# Altium schematic sheet power_do.SchDoc(power_do)
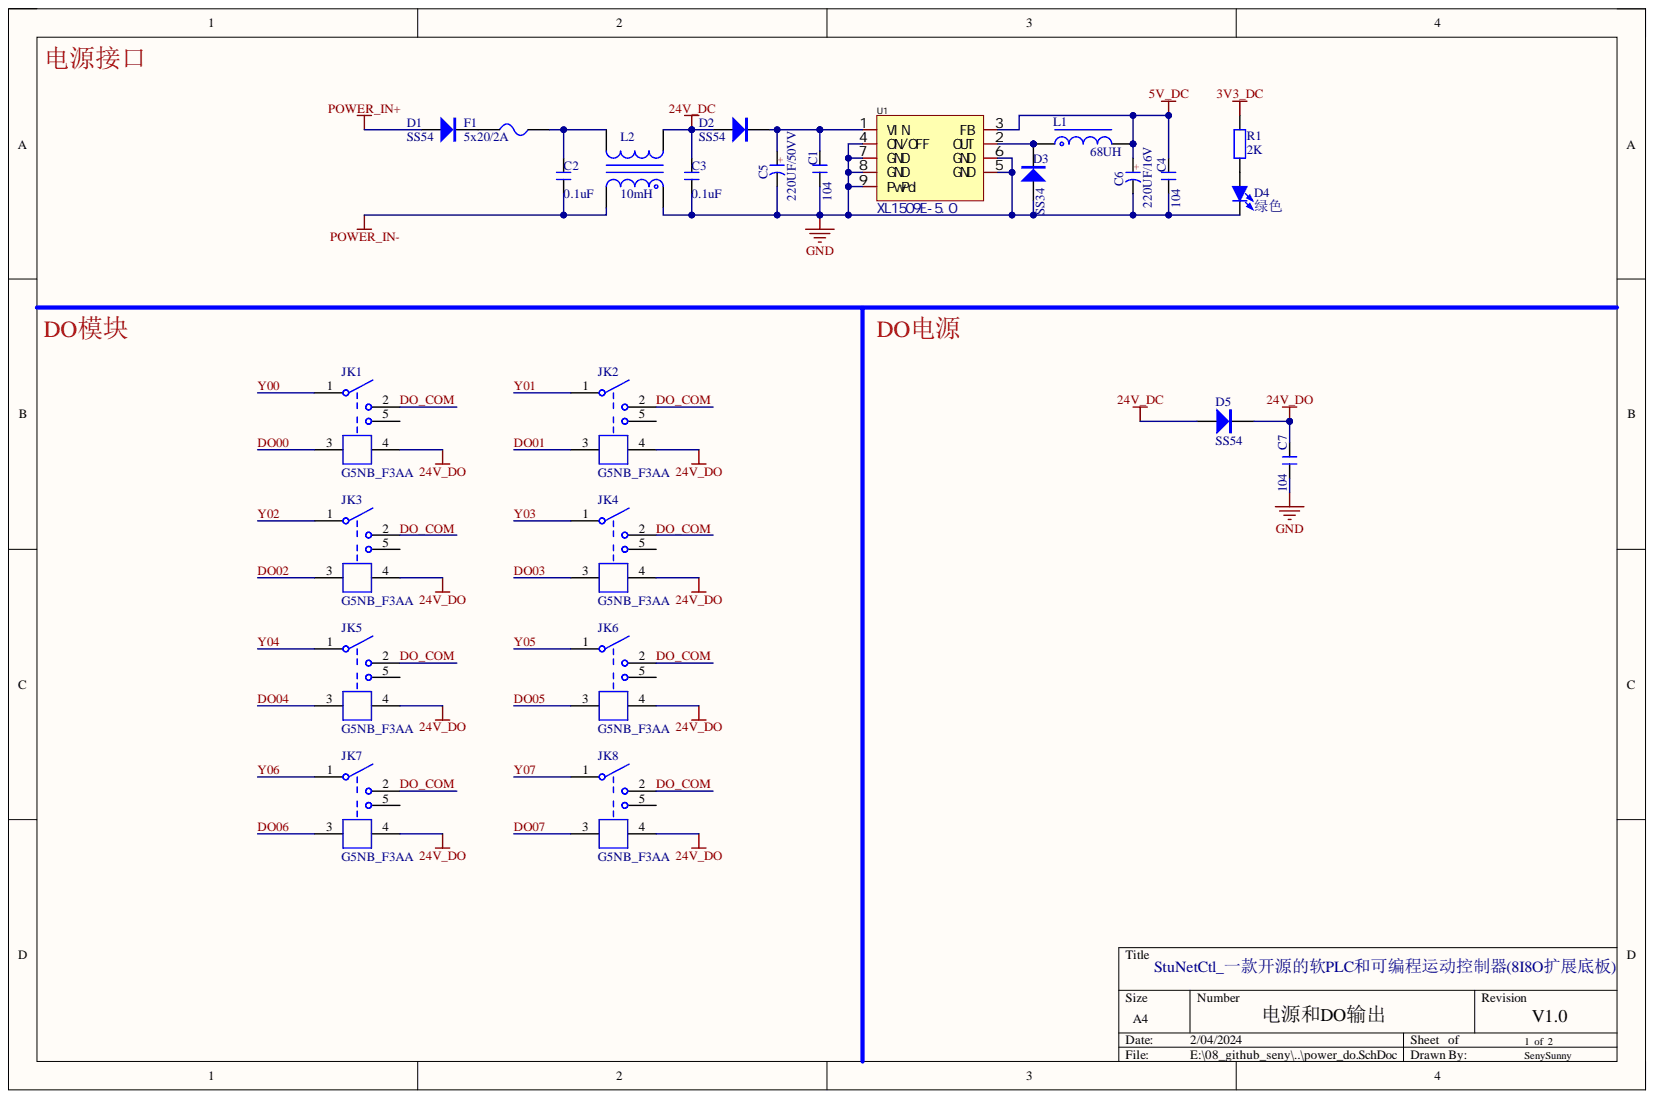
[diagram p0: power_do.SchDoc]

## Parts
C1  104  [C 0603]
C2  0.1uF  [CBB 6.3*6.3*5]
C3  0.1uF  [CBB 6.3*6.3*5]
C4  104  [C 0603]
C5  220UF/50VV  [CAP 5.0*10*12.5 - BK]
C6  220UF/16V  [CAP 2.5*6.3*11.2 - BK]
C7  104  [C 0603]
D1  SS54  [SMA(DO214AC)]
D2  SS54  [SMA(DO214AC)]
D3  SS34  [SMA(DO214AC)]
D4  绿色  [LED 0603G]
D5  SS54  [SMA(DO214AC)]
F1  5x20/2A  [FU RL30-200]
JK1  G5NB_F3AA  [G5NB_F3AA]
JK2  G5NB_F3AA  [G5NB_F3AA]
JK3  G5NB_F3AA  [G5NB_F3AA]
JK4  G5NB_F3AA  [G5NB_F3AA]
JK5  G5NB_F3AA  [G5NB_F3AA]
JK6  G5NB_F3AA  [G5NB_F3AA]
JK7  G5NB_F3AA  [G5NB_F3AA]
JK8  G5NB_F3AA  [G5NB_F3AA]
L1  68UH  [CDRH127]
L2  10mH  [UU9.8]
R1  2K  [R 0603]
U1  XL1509E-5.0  [SOP8P_N]

## Nets
3V3_DC: R1.1
5V_DC: C4.2 C6.1 L1.2 U1.3(FB)
24V_DC: C3.2 D2.1 D5.1 L2.4
24V_DO: C7.1 D5.2 JK1.4 JK2.4 JK3.4 JK4.4 JK5.4 JK6.4 JK7.4 JK8.4
GND: C1.1 C3.1 C4.1 C5.2 C6.2 C7.2 D3.1 D4.2 L2.1 U1.4(ON/OFF) U1.5(GND) U1.6(GND) U1.7(GND) U1.8(GND) U1.9(PwPd)
POWER_IN+: D1.1
POWER_IN-: C2.1 L2.2
DO00: JK1.3
DO01: JK2.3
DO02: JK3.3
DO03: JK4.3
DO04: JK5.3
DO05: JK6.3
DO06: JK7.3
DO07: JK8.3
DO_COM: JK1.2 JK2.2 JK3.2 JK4.2 JK5.2 JK6.2 JK7.2 JK8.2
Y00: JK1.1
Y01: JK2.1
Y02: JK3.1
Y03: JK4.1
Y04: JK5.1
Y05: JK6.1
Y06: JK7.1
Y07: JK8.1
NetC1_2: C1.2 C5.1 D2.2 U1.1(VIN)
NetC2_2: C2.2 F1.1 L2.3
NetD1_2: D1.2 F1.2
NetD3_2: D3.2 L1.1 U1.2(OUT)
NetD4_1: D4.1 R1.2

Not connected: JK1 5; JK2 5; JK3 5; JK4 5; JK5 5; JK6 5; JK7 5; JK8 5

## Library data of the parts
C1 C4 C7 = C: 无极性贴片电容; 版权归属: 源创客; BOM名称: 无极性贴片电容; Component Kind: Standard; 学习资源: www.ooocke.com; Library Name: zblib_v1.IntLib
C2 C3 = C-CBB: CBB电容; 版权归属: 源创客; BOM名称: CBB电容; Component Kind: Standard; 学习资源: www.ooocke.com; Library Name: zblib_v1.IntLib
C5 C6 = C-CAP: 直插电解电容; 版权归属: 源创客; BOM名称: 直插电解电容; Component Kind: Standard; 学习资源: www.ooocke.com; Library Name: zblib_v1.IntLib
D1-D3 D5 = SS14: 肖特基二极管; 属性: 40V/1A; 版权归属: 源创客; BOM名称: 肖特基二极管; Component Kind: Standard; 学习资源: www.ooocke.com; Library Name: zblib_v1.IntLib
D4 = LED-SMD: 贴片LED; 版权归属: 源创客; BOM名称: 贴片LED; Component Kind: Standard; 学习资源: www.ooocke.com; Library Name: zblib_v1.IntLib
F1 = FU DIP: 直插保险丝; 版权归属: 源创客; BOM名称: 直插保险丝; Component Kind: Standard; 学习资源: www.ooocke.com; Library Name: zblib_v1.IntLib
JK1-JK8 = G5NB_F3AA: 单路常开继电器; 版权归属: 源创客; BOM名称: 单路常开继电器; Component Kind: Standard; 学习资源: www.ooocke.com; Library Name: zblib_v1.IntLib
L1 = L-CDRH: 功率屏蔽电感; 版权归属: 源创客; BOM名称: 功率屏蔽电感; Component Kind: Standard; 学习资源: www.ooocke.com; Library Name: zblib_v1.IntLib
L2 = L-CMC: 共模电感; 版权归属: 源创客; BOM名称: 共模电感; Component Kind: Standard; 学习资源: www.ooocke.com; Library Name: zblib_v1.IntLib
R1 = R: 贴片电阻; 版权归属: 源创客; BOM名称: 贴片电阻; Component Kind: Standard; 学习资源: www.ooocke.com; Library Name: zblib_v1.IntLib
U1 = XL1509E-5.0: DC-DC电源芯片; Manufacturer: UMW(广东友台半导体); Add into BOM: yes; Device: XL1509-5.0; Symbol: XL1509-5.0_C2902368; Component Kind: Standard; Convert to PCB: yes; Datasheet: https://atta.szlcsc.com/upload/public/pdf/source/20230412/A4F395BE4384B04485E6C784EB623363.pdf; JLCPCB Part Class: Extended Part; LCSC Part Name: XL1509-5.0; Manufacturer Part: XL1509-5.0; Name: XL1509-5.0; Supplier: LCSC; Supplier Footprint: SOIC-8; Supplier Part: C2902368; Library Name: zblib_v1.IntLib
